# Altium schematic sheet TOP_VOLTAGE.SchDoc
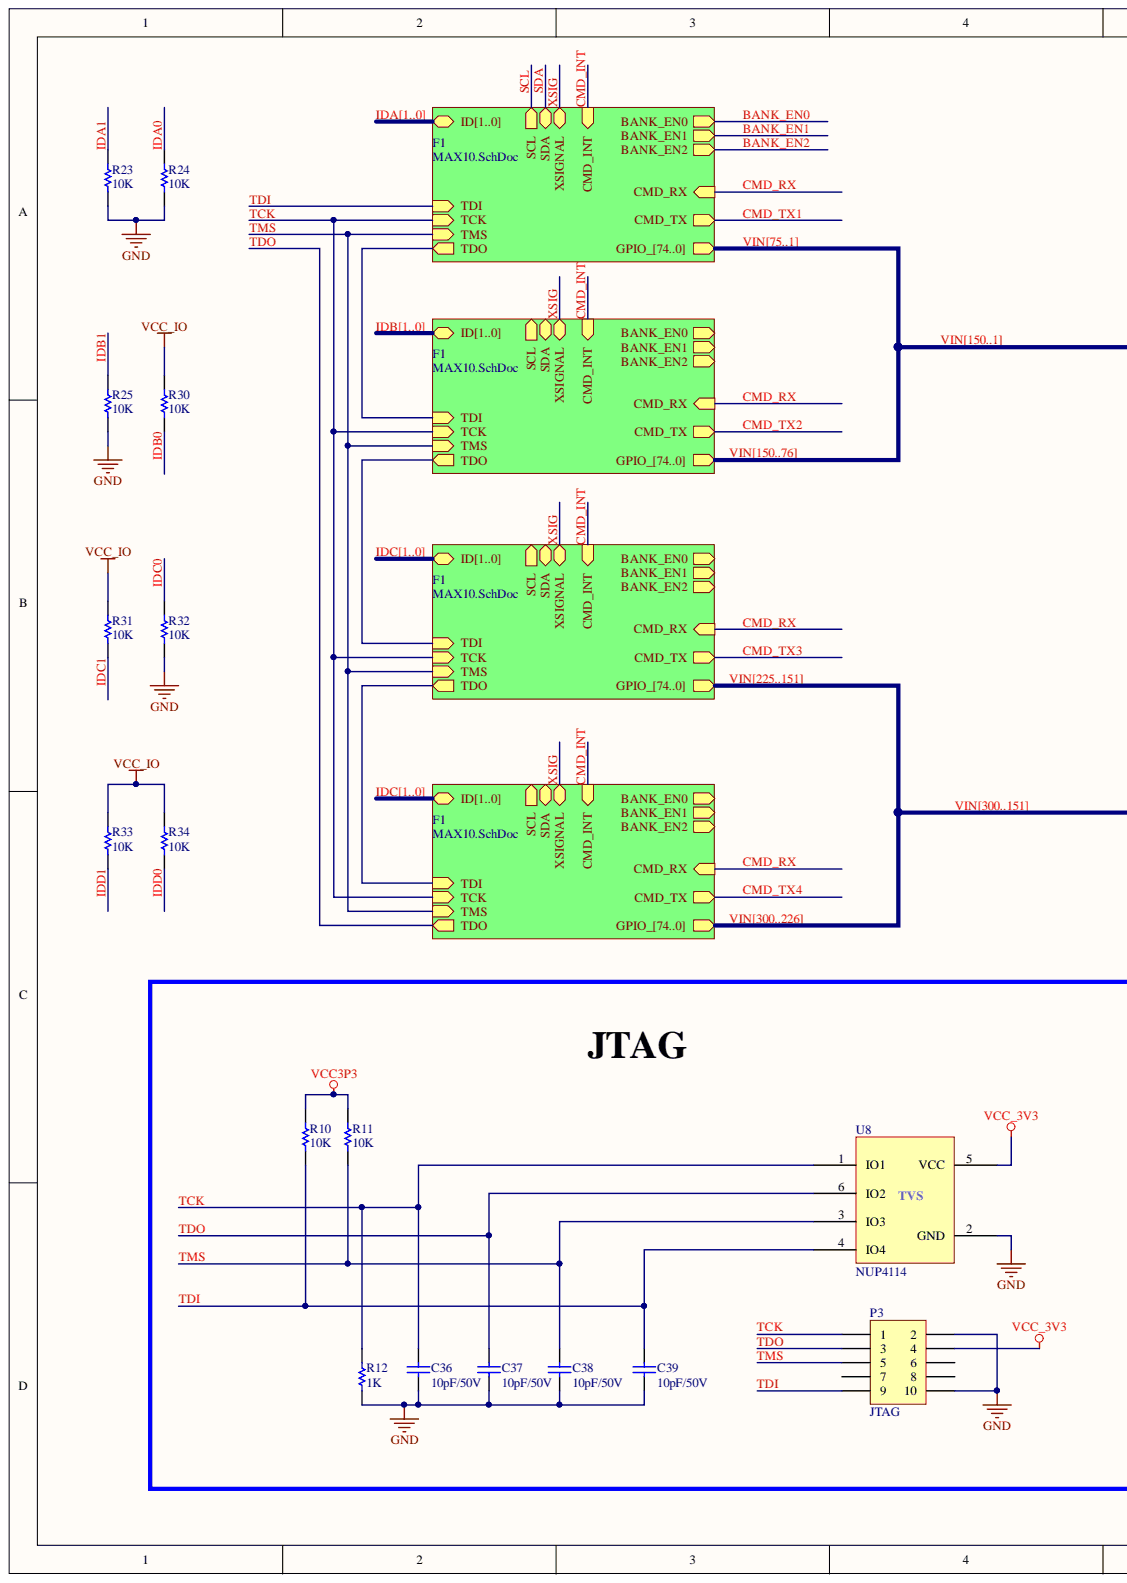
[diagram p0: TOP_VOLTAGE.SchDoc, left]
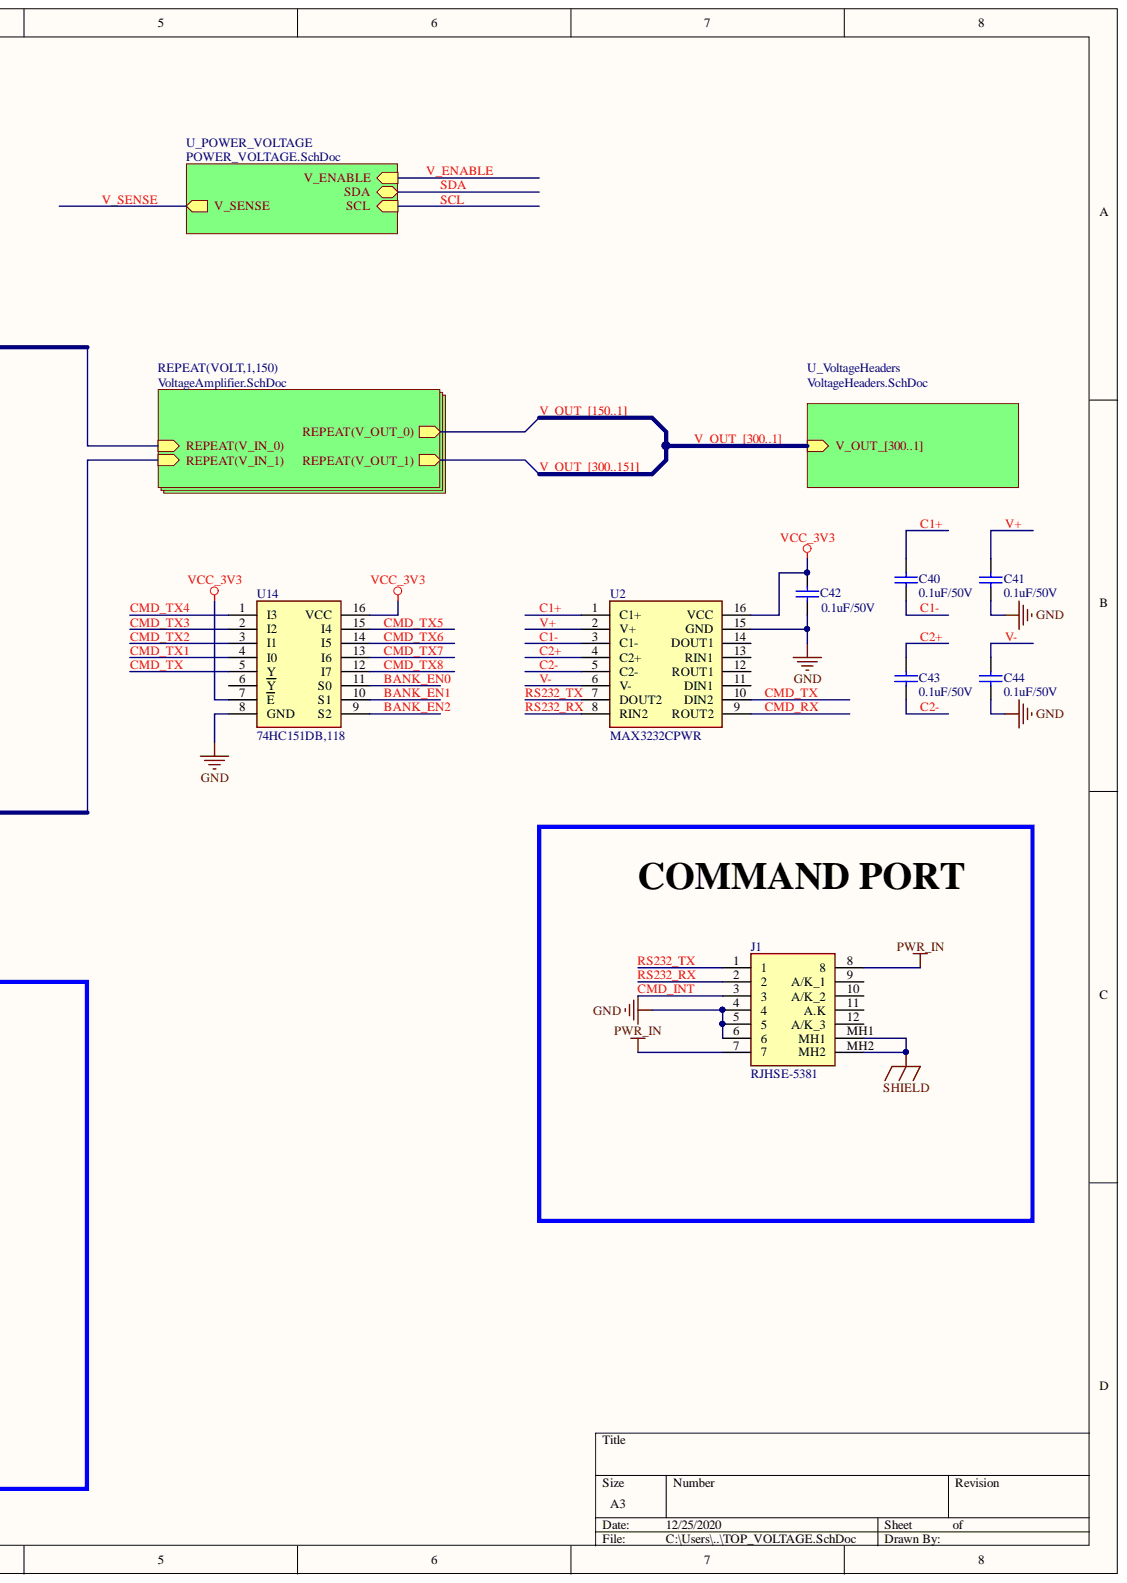
[diagram p1: TOP_VOLTAGE.SchDoc, right]

## Parts
C36  10pF/50V
C37  10pF/50V
C38  10pF/50V
C39  10pF/50V
C40  0.1uF/50V
C41  0.1uF/50V
C42  0.1uF/50V
C43  0.1uF/50V
C44  0.1uF/50V
J1  RJHSE-5381  [RJHSE5381]
P3  JTAG  [HDR2X5]  (no BOM)
R10  10K
R11  10K
R12  1K  [AXIAL-0.3]
R23  10K
R24  10K
R25  10K
R30  10K
R31  10K
R32  10K
R33  10K
R34  10K
U2  MAX3232CPWR  [SOP65P640X120-16N]
U8  NUP4114  value TVS
U14  74HC151DB,118  [SOP65P775X200-16N]

## Nets
GND: C36.1 C37.1 C38.1 C39.1 C41.1 C42.1 C44.1 J1.4 J1.5 J1.6 P3.2 P3.10 R12.1 R23.2 R24.2 R25.2 R32.2 U2.15(GND) U8.2(GND) U14.8(GND)
PWR_IN: J1.7 J1.8
SHIELD: J1.MH1 J1.MH2
VCC3P3: R10.1 R11.1
VCC_3V3: C42.2 P3.4 U2.16(VCC) U8.5(VCC) U14.7(E) U14.16(VCC)
VCC_IO: R30.1 R31.1 R33.1 R34.1
BANK_EN0: U14.11(S0)
BANK_EN1: U14.10(S1)
BANK_EN2: U14.9(S2)
C1+: C40.2 U2.1(C1+)
C1-: C40.1 U2.3(C1-)
C2+: C43.2 U2.4(C2+)
C2-: C43.1 U2.5(C2-)
CMD_INT: J1.3
CMD_RX: U2.9(ROUT2)
CMD_TX: U2.10(DIN2) U14.5(Y)
CMD_TX1: U14.4(I0)
CMD_TX2: U14.3(I1)
CMD_TX3: U14.2(I2)
CMD_TX4: U14.1(I3)
CMD_TX5: U14.15(I4)
CMD_TX6: U14.14(I5)
CMD_TX7: U14.13(I6)
CMD_TX8: U14.12(I7)
IDA0: R24.1  [also labelled IDA]
IDA1: R23.1  [also labelled IDA]
IDB0: R30.2  [also labelled IDB]
IDB1: R25.1  [also labelled IDB]
IDC0: R32.1  [also labelled IDC]
IDC1: R31.2  [also labelled IDC]
IDD0: R34.2
IDD1: R33.2
RS232_RX: J1.2 U2.8(RIN2)
RS232_TX: J1.1 U2.7(DOUT2)
TCK: C36.2 P3.1 R12.2 U8.1(IO1)
TDI: C39.2 P3.9 R10.2 U8.4(IO4)
TDO: C37.2 P3.3 U8.6(IO2)
TMS: C38.2 P3.5 R11.2 U8.3(IO3)
V+: C41.2 U2.2(V+)
V-: C44.2 U2.6(V-)
NetJ1_9: J1.9(A/K_1)
NetJ1_10: J1.10(A/K_2)
NetJ1_11: J1.11(A.K)
NetJ1_12: J1.12(A/K_3)
NetP3_6: P3.6
NetP3_7: P3.7
NetP3_8: P3.8
NetU2_11: U2.11(DIN1)
NetU2_12: U2.12(ROUT1)
NetU2_13: U2.13(RIN1)
NetU2_14: U2.14(DOUT1)
NetU14_6: U14.6(Y)
SCL:
SDA:
V_ENABLE:
V_IN_0:
V_IN_1:
V_OUT_0:
V_OUT_1:
V_OUT_151:  [also labelled V_OUT_]
V_OUT_152:  [also labelled V_OUT_]
V_OUT_153:  [also labelled V_OUT_]
V_OUT_154:  [also labelled V_OUT_]
V_OUT_155:  [also labelled V_OUT_]
V_OUT_156:  [also labelled V_OUT_]
V_OUT_157:  [also labelled V_OUT_]
V_OUT_158:  [also labelled V_OUT_]
V_OUT_159:  [also labelled V_OUT_]
V_OUT_160:  [also labelled V_OUT_]
V_OUT_161:  [also labelled V_OUT_]
V_OUT_162:  [also labelled V_OUT_]
V_OUT_163:  [also labelled V_OUT_]
V_OUT_164:  [also labelled V_OUT_]
V_OUT_165:  [also labelled V_OUT_]
V_OUT_166:  [also labelled V_OUT_]
V_OUT_167:  [also labelled V_OUT_]
V_OUT_168:  [also labelled V_OUT_]
V_OUT_169:  [also labelled V_OUT_]
V_OUT_170:  [also labelled V_OUT_]
V_OUT_171:  [also labelled V_OUT_]
V_OUT_172:  [also labelled V_OUT_]
V_OUT_173:  [also labelled V_OUT_]
V_OUT_174:  [also labelled V_OUT_]
V_OUT_175:  [also labelled V_OUT_]
V_OUT_176:  [also labelled V_OUT_]
V_OUT_177:  [also labelled V_OUT_]
V_OUT_178:  [also labelled V_OUT_]
V_OUT_179:  [also labelled V_OUT_]
V_OUT_180:  [also labelled V_OUT_]
V_OUT_181:  [also labelled V_OUT_]
V_OUT_182:  [also labelled V_OUT_]
V_OUT_183:  [also labelled V_OUT_]
V_OUT_184:  [also labelled V_OUT_]
V_OUT_185:  [also labelled V_OUT_]
V_OUT_186:  [also labelled V_OUT_]
V_OUT_187:  [also labelled V_OUT_]
V_OUT_188:  [also labelled V_OUT_]
V_OUT_189:  [also labelled V_OUT_]
V_OUT_190:  [also labelled V_OUT_]
V_OUT_191:  [also labelled V_OUT_]
V_OUT_192:  [also labelled V_OUT_]
V_OUT_193:  [also labelled V_OUT_]
V_OUT_194:  [also labelled V_OUT_]
V_OUT_195:  [also labelled V_OUT_]
V_OUT_196:  [also labelled V_OUT_]
V_OUT_197:  [also labelled V_OUT_]
V_OUT_198:  [also labelled V_OUT_]
V_OUT_199:  [also labelled V_OUT_]
V_OUT_200:  [also labelled V_OUT_]
V_OUT_201:  [also labelled V_OUT_]
V_OUT_202:  [also labelled V_OUT_]
V_OUT_203:  [also labelled V_OUT_]
V_OUT_204:  [also labelled V_OUT_]
V_OUT_205:  [also labelled V_OUT_]
V_OUT_206:  [also labelled V_OUT_]
V_OUT_207:  [also labelled V_OUT_]
V_OUT_208:  [also labelled V_OUT_]
V_OUT_209:  [also labelled V_OUT_]
V_OUT_210:  [also labelled V_OUT_]
V_OUT_211:  [also labelled V_OUT_]
V_OUT_212:  [also labelled V_OUT_]
V_OUT_213:  [also labelled V_OUT_]
V_OUT_214:  [also labelled V_OUT_]
V_OUT_215:  [also labelled V_OUT_]
V_OUT_216:  [also labelled V_OUT_]
V_OUT_217:  [also labelled V_OUT_]
V_OUT_218:  [also labelled V_OUT_]
V_OUT_219:  [also labelled V_OUT_]
V_OUT_220:  [also labelled V_OUT_]
V_OUT_221:  [also labelled V_OUT_]
V_OUT_222:  [also labelled V_OUT_]
V_OUT_223:  [also labelled V_OUT_]
V_OUT_224:  [also labelled V_OUT_]
V_OUT_225:  [also labelled V_OUT_]
V_OUT_226:  [also labelled V_OUT_]
V_OUT_227:  [also labelled V_OUT_]
V_OUT_228:  [also labelled V_OUT_]
V_OUT_229:  [also labelled V_OUT_]
V_OUT_230:  [also labelled V_OUT_]
V_OUT_231:  [also labelled V_OUT_]
V_OUT_232:  [also labelled V_OUT_]
V_OUT_233:  [also labelled V_OUT_]
V_OUT_234:  [also labelled V_OUT_]
V_OUT_235:  [also labelled V_OUT_]
V_OUT_236:  [also labelled V_OUT_]
V_OUT_237:  [also labelled V_OUT_]
V_OUT_238:  [also labelled V_OUT_]
V_OUT_239:  [also labelled V_OUT_]
V_OUT_240:  [also labelled V_OUT_]
V_OUT_241:  [also labelled V_OUT_]
V_OUT_242:  [also labelled V_OUT_]
V_OUT_243:  [also labelled V_OUT_]
V_OUT_244:  [also labelled V_OUT_]
V_OUT_245:  [also labelled V_OUT_]
V_OUT_246:  [also labelled V_OUT_]
V_OUT_247:  [also labelled V_OUT_]
V_OUT_248:  [also labelled V_OUT_]
V_OUT_249:  [also labelled V_OUT_]
V_OUT_250:  [also labelled V_OUT_]
V_OUT_251:  [also labelled V_OUT_]
V_OUT_252:  [also labelled V_OUT_]
V_OUT_253:  [also labelled V_OUT_]
V_OUT_254:  [also labelled V_OUT_]
V_OUT_255:  [also labelled V_OUT_]
V_OUT_256:  [also labelled V_OUT_]
V_OUT_257:  [also labelled V_OUT_]
V_OUT_258:  [also labelled V_OUT_]
V_OUT_259:  [also labelled V_OUT_]
V_OUT_260:  [also labelled V_OUT_]
V_OUT_261:  [also labelled V_OUT_]
V_OUT_262:  [also labelled V_OUT_]
V_OUT_263:  [also labelled V_OUT_]
V_OUT_264:  [also labelled V_OUT_]
V_OUT_265:  [also labelled V_OUT_]
V_OUT_266:  [also labelled V_OUT_]
V_OUT_267:  [also labelled V_OUT_]
V_OUT_268:  [also labelled V_OUT_]
V_OUT_269:  [also labelled V_OUT_]
V_OUT_270:  [also labelled V_OUT_]
V_OUT_271:  [also labelled V_OUT_]
V_OUT_272:  [also labelled V_OUT_]
V_OUT_273:  [also labelled V_OUT_]
V_OUT_274:  [also labelled V_OUT_]
V_OUT_275:  [also labelled V_OUT_]
V_OUT_276:  [also labelled V_OUT_]
V_OUT_277:  [also labelled V_OUT_]
V_OUT_278:  [also labelled V_OUT_]
V_OUT_279:  [also labelled V_OUT_]
V_OUT_280:  [also labelled V_OUT_]
V_OUT_281:  [also labelled V_OUT_]
V_OUT_282:  [also labelled V_OUT_]
V_OUT_283:  [also labelled V_OUT_]
V_OUT_284:  [also labelled V_OUT_]
V_OUT_285:  [also labelled V_OUT_]
V_OUT_286:  [also labelled V_OUT_]
V_OUT_287:  [also labelled V_OUT_]
V_OUT_288:  [also labelled V_OUT_]
V_OUT_289:  [also labelled V_OUT_]
V_OUT_290:  [also labelled V_OUT_]
V_OUT_291:  [also labelled V_OUT_]
V_OUT_292:  [also labelled V_OUT_]
V_OUT_293:  [also labelled V_OUT_]
V_OUT_294:  [also labelled V_OUT_]
V_OUT_295:  [also labelled V_OUT_]
V_OUT_296:  [also labelled V_OUT_]
V_OUT_297:  [also labelled V_OUT_]
V_OUT_298:  [also labelled V_OUT_]
V_OUT_299:  [also labelled V_OUT_]
V_OUT_300:  [also labelled V_OUT_]
V_SENSE:
VIN76:  [also labelled VIN]
VIN77:  [also labelled VIN]
VIN78:  [also labelled VIN]
VIN79:  [also labelled VIN]
VIN80:  [also labelled VIN]
VIN81:  [also labelled VIN]
VIN82:  [also labelled VIN]
VIN83:  [also labelled VIN]
VIN84:  [also labelled VIN]
VIN85:  [also labelled VIN]
VIN86:  [also labelled VIN]
VIN87:  [also labelled VIN]
VIN88:  [also labelled VIN]
VIN89:  [also labelled VIN]
VIN90:  [also labelled VIN]
VIN91:  [also labelled VIN]
VIN92:  [also labelled VIN]
VIN93:  [also labelled VIN]
VIN94:  [also labelled VIN]
VIN95:  [also labelled VIN]
VIN96:  [also labelled VIN]
VIN97:  [also labelled VIN]
VIN98:  [also labelled VIN]
VIN99:  [also labelled VIN]
VIN100:  [also labelled VIN]
VIN101:  [also labelled VIN]
VIN102:  [also labelled VIN]
VIN103:  [also labelled VIN]
VIN104:  [also labelled VIN]
VIN105:  [also labelled VIN]
VIN106:  [also labelled VIN]
VIN107:  [also labelled VIN]
VIN108:  [also labelled VIN]
VIN109:  [also labelled VIN]
VIN110:  [also labelled VIN]
VIN111:  [also labelled VIN]
VIN112:  [also labelled VIN]
VIN113:  [also labelled VIN]
VIN114:  [also labelled VIN]
VIN115:  [also labelled VIN]
VIN116:  [also labelled VIN]
VIN117:  [also labelled VIN]
VIN118:  [also labelled VIN]
VIN119:  [also labelled VIN]
VIN120:  [also labelled VIN]
VIN121:  [also labelled VIN]
VIN122:  [also labelled VIN]
VIN123:  [also labelled VIN]
VIN124:  [also labelled VIN]
VIN125:  [also labelled VIN]
VIN126:  [also labelled VIN]
VIN127:  [also labelled VIN]
VIN128:  [also labelled VIN]
VIN129:  [also labelled VIN]
VIN130:  [also labelled VIN]
VIN131:  [also labelled VIN]
VIN132:  [also labelled VIN]
VIN133:  [also labelled VIN]
VIN134:  [also labelled VIN]
VIN135:  [also labelled VIN]
VIN136:  [also labelled VIN]
VIN137:  [also labelled VIN]
VIN138:  [also labelled VIN]
VIN139:  [also labelled VIN]
VIN140:  [also labelled VIN]
VIN141:  [also labelled VIN]
VIN142:  [also labelled VIN]
VIN143:  [also labelled VIN]
VIN144:  [also labelled VIN]
VIN145:  [also labelled VIN]
VIN146:  [also labelled VIN]
VIN147:  [also labelled VIN]
VIN148:  [also labelled VIN]
VIN149:  [also labelled VIN]
VIN150:  [also labelled VIN]
VIN226:  [also labelled VIN]
VIN227:  [also labelled VIN]
VIN228:  [also labelled VIN]
VIN229:  [also labelled VIN]
VIN230:  [also labelled VIN]
VIN231:  [also labelled VIN]
VIN232:  [also labelled VIN]
VIN233:  [also labelled VIN]
VIN234:  [also labelled VIN]
VIN235:  [also labelled VIN]
VIN236:  [also labelled VIN]
VIN237:  [also labelled VIN]
VIN238:  [also labelled VIN]
VIN239:  [also labelled VIN]
VIN240:  [also labelled VIN]
VIN241:  [also labelled VIN]
VIN242:  [also labelled VIN]
VIN243:  [also labelled VIN]
VIN244:  [also labelled VIN]
VIN245:  [also labelled VIN]
VIN246:  [also labelled VIN]
VIN247:  [also labelled VIN]
VIN248:  [also labelled VIN]
VIN249:  [also labelled VIN]
VIN250:  [also labelled VIN]
VIN251:  [also labelled VIN]
VIN252:  [also labelled VIN]
VIN253:  [also labelled VIN]
VIN254:  [also labelled VIN]
VIN255:  [also labelled VIN]
VIN256:  [also labelled VIN]
VIN257:  [also labelled VIN]
VIN258:  [also labelled VIN]
VIN259:  [also labelled VIN]
VIN260:  [also labelled VIN]
VIN261:  [also labelled VIN]
VIN262:  [also labelled VIN]
VIN263:  [also labelled VIN]
VIN264:  [also labelled VIN]
VIN265:  [also labelled VIN]
VIN266:  [also labelled VIN]
VIN267:  [also labelled VIN]
VIN268:  [also labelled VIN]
VIN269:  [also labelled VIN]
VIN270:  [also labelled VIN]
VIN271:  [also labelled VIN]
VIN272:  [also labelled VIN]
VIN273:  [also labelled VIN]
VIN274:  [also labelled VIN]
VIN275:  [also labelled VIN]
VIN276:  [also labelled VIN]
VIN277:  [also labelled VIN]
VIN278:  [also labelled VIN]
VIN279:  [also labelled VIN]
VIN280:  [also labelled VIN]
VIN281:  [also labelled VIN]
VIN282:  [also labelled VIN]
VIN283:  [also labelled VIN]
VIN284:  [also labelled VIN]
VIN285:  [also labelled VIN]
VIN286:  [also labelled VIN]
VIN287:  [also labelled VIN]
VIN288:  [also labelled VIN]
VIN289:  [also labelled VIN]
VIN290:  [also labelled VIN]
VIN291:  [also labelled VIN]
VIN292:  [also labelled VIN]
VIN293:  [also labelled VIN]
VIN294:  [also labelled VIN]
VIN295:  [also labelled VIN]
VIN296:  [also labelled VIN]
VIN297:  [also labelled VIN]
VIN298:  [also labelled VIN]
VIN299:  [also labelled VIN]
VIN300:  [also labelled VIN]
XSIG:

## Library data of the parts
C36-C39 = Cap: Multilayer Ceramic Capacitors MLCC - SMD/SMT 50V 10pF 0603 C0G 5% AEC-Q200; Mfr: KEMET; Mfr Part No: C0603C100J5GACAUTO; Component Kind: Standard; Library Name: Miscellaneous Devices.IntLib; Package: 0603; Price: 0.192; Signal Integrity: Cap; Simulation: CAP; Stockiest: MOUSER; Stockiest Part No: 80-C0603C100J5GAUTO; Value: 10pF/50V
C40-C44 = Cap: Multilayer Ceramic Capacitors MLCC - SMD/SMT 50V 0.1uF 0603 X7R 20%; Mfr: KEMET; Mfr Part No: C0603C104M5RACTU; Component Kind: Standard; Library Name: Miscellaneous Devices.IntLib; Package: 0603; Price: 0.101; Signal Integrity: Cap; Simulation: CAP; Stockiest: MOUSER; Stockiest Part No: 80-C0603C104M5R; Value: 0.1uF/50V
J1 = RJHSE-5381: Modular Connectors / Ethernet Connectors R/A RJ45 SHEILDED 8 CONT Y/G LEDS; Mfr: Amphenol Commercial Products; Component Kind: Standard; Mfr Part No: RJHSE-5381; Price: 1.77; Stockiest: MOUSER; Stockiest Part No: 523-RJHSE-5381
P3 = Header 5X2: Header, 5-Pin, Dual row; Library Name: Miscellaneous Connectors.IntLib; Signal Integrity: Connector; Stockiest: MOUSER
R10 R11 R23-R25 R30-R34 = Res1: Thick Film Resistors - SMD 0603 10Kohms 1% Anti-Sulfur AEC-Q200; Mfr: ROHM Semiconductor; Mfr Part No: SFR03EZPF1002; Component Kind: Standard; Library Name: Miscellaneous Devices.IntLib; Package: 0603; Price: 0.101; Signal Integrity: Res; Simulation: RESISTOR; Stockiest: MOUSER; Stockiest Part No: 755-SFR03EZPF1002; Value: 10K
R12 = Res1: Resistor; Mfr: Vishay / Dale; Mfr Part No: CRCW06031K00FKEAC; Component Kind: Standard; Library Name: Miscellaneous Devices.IntLib; Package: 0603; Price: 0.1; Signal Integrity: Res; Simulation: RESISTOR; Stockiest: MOUSER; Stockiest Part No: 71-CRCW06031K00FKEAC; Value: 1K
U2 = MAX3232CPWR: RS-232 Interface IC 3-5.5V Mult-Ch RS232; Mfr: Texas Instruments; Component Kind: Standard; Mfr Part No: MAX3232CPWR; Price: 0.94; Stockiest: MOUSER; Stockiest Part No: 595-MAX3232CPWR
U8 = NUP4114: ESD Suppressors / TVS Diodes LOW CAP TVS ARRAY 5.5V; Mfr: ON SEMI; Mfr Part No: NUP4114HMR6T1G; Component Kind: Standard; Library Name: Schlib1.SchLib; Price: 0.534; Stockiest: MOUSER; Stockiest Part No: 863-NUP4114HMR6T1G
U14 = 74HC151DB,118: Encoders, Decoders, Multiplexers & Demultiplexers 8-INPUT MULTIPLEXER; Mfr: NEXPERIA; Component Kind: Standard; Mfr Part No: 74HC151DB,118; Price: 0.887; Stockiest: MOUSER; Stockiest Part No: 771-HC151DB118
